# Altium schematic sheet HEATER.SchDoc(HEATER)
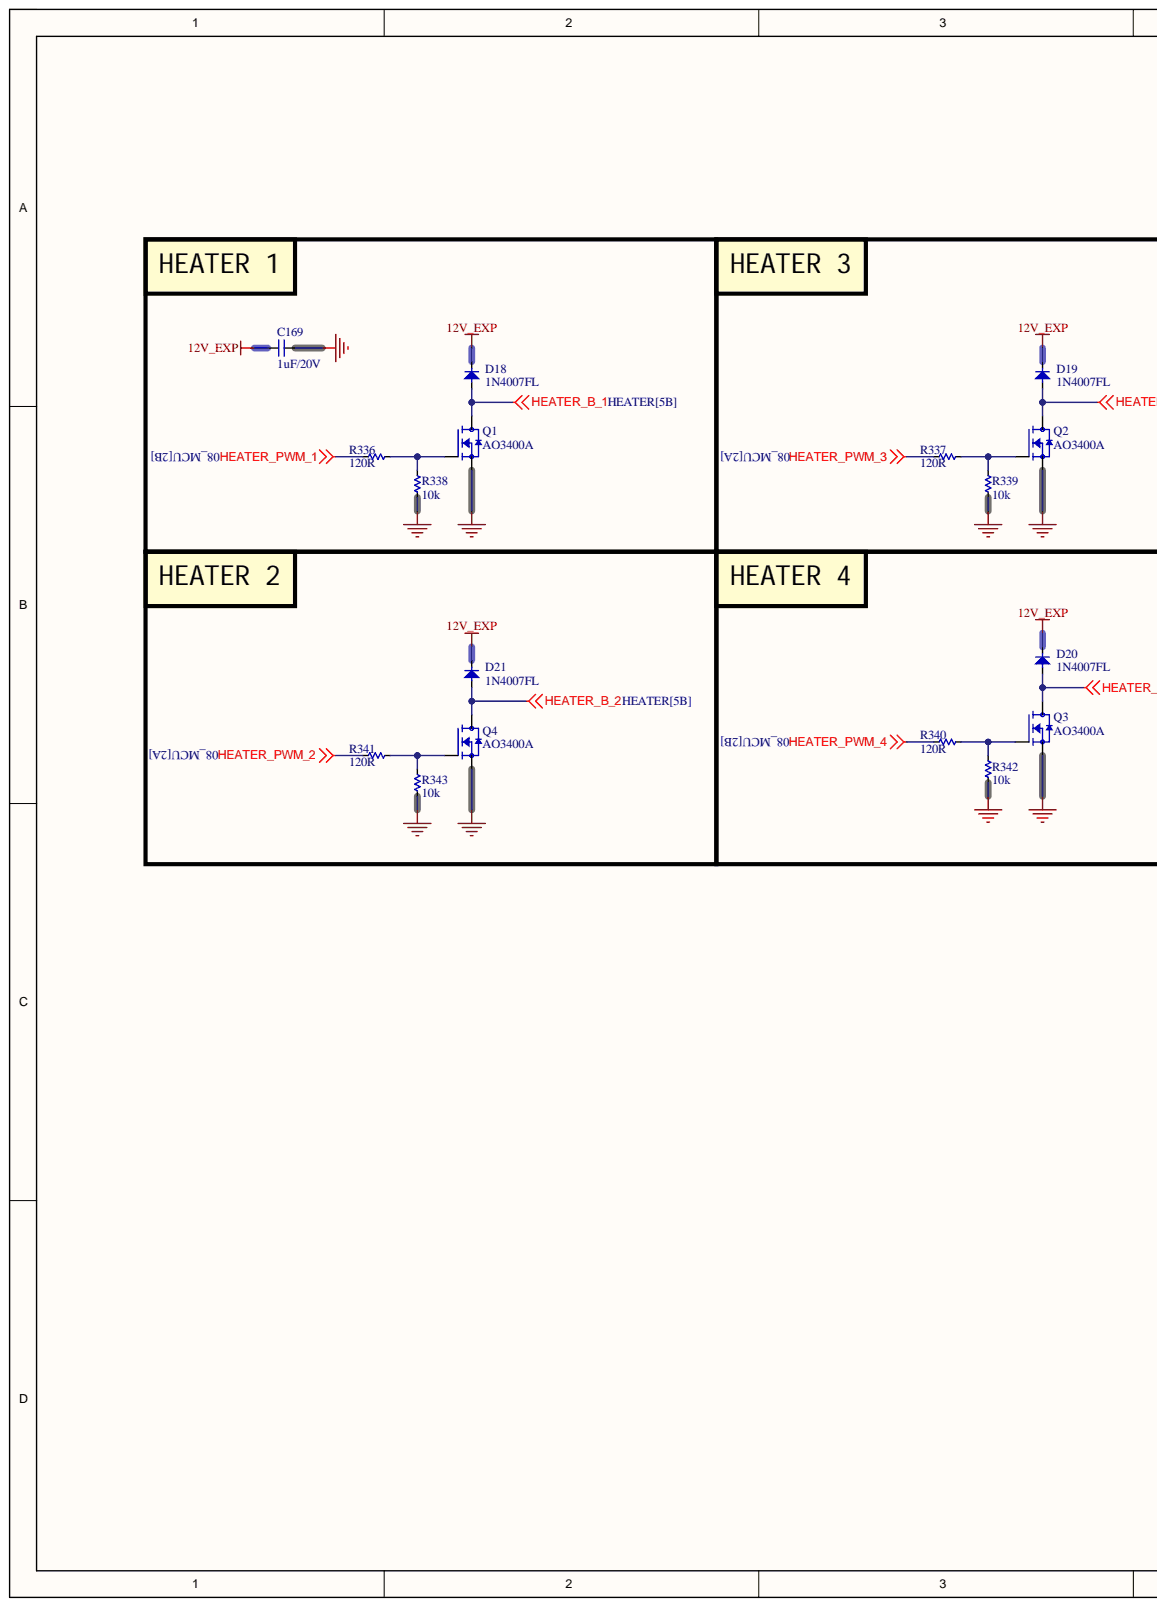
[diagram p0: HEATER.SchDoc, left]
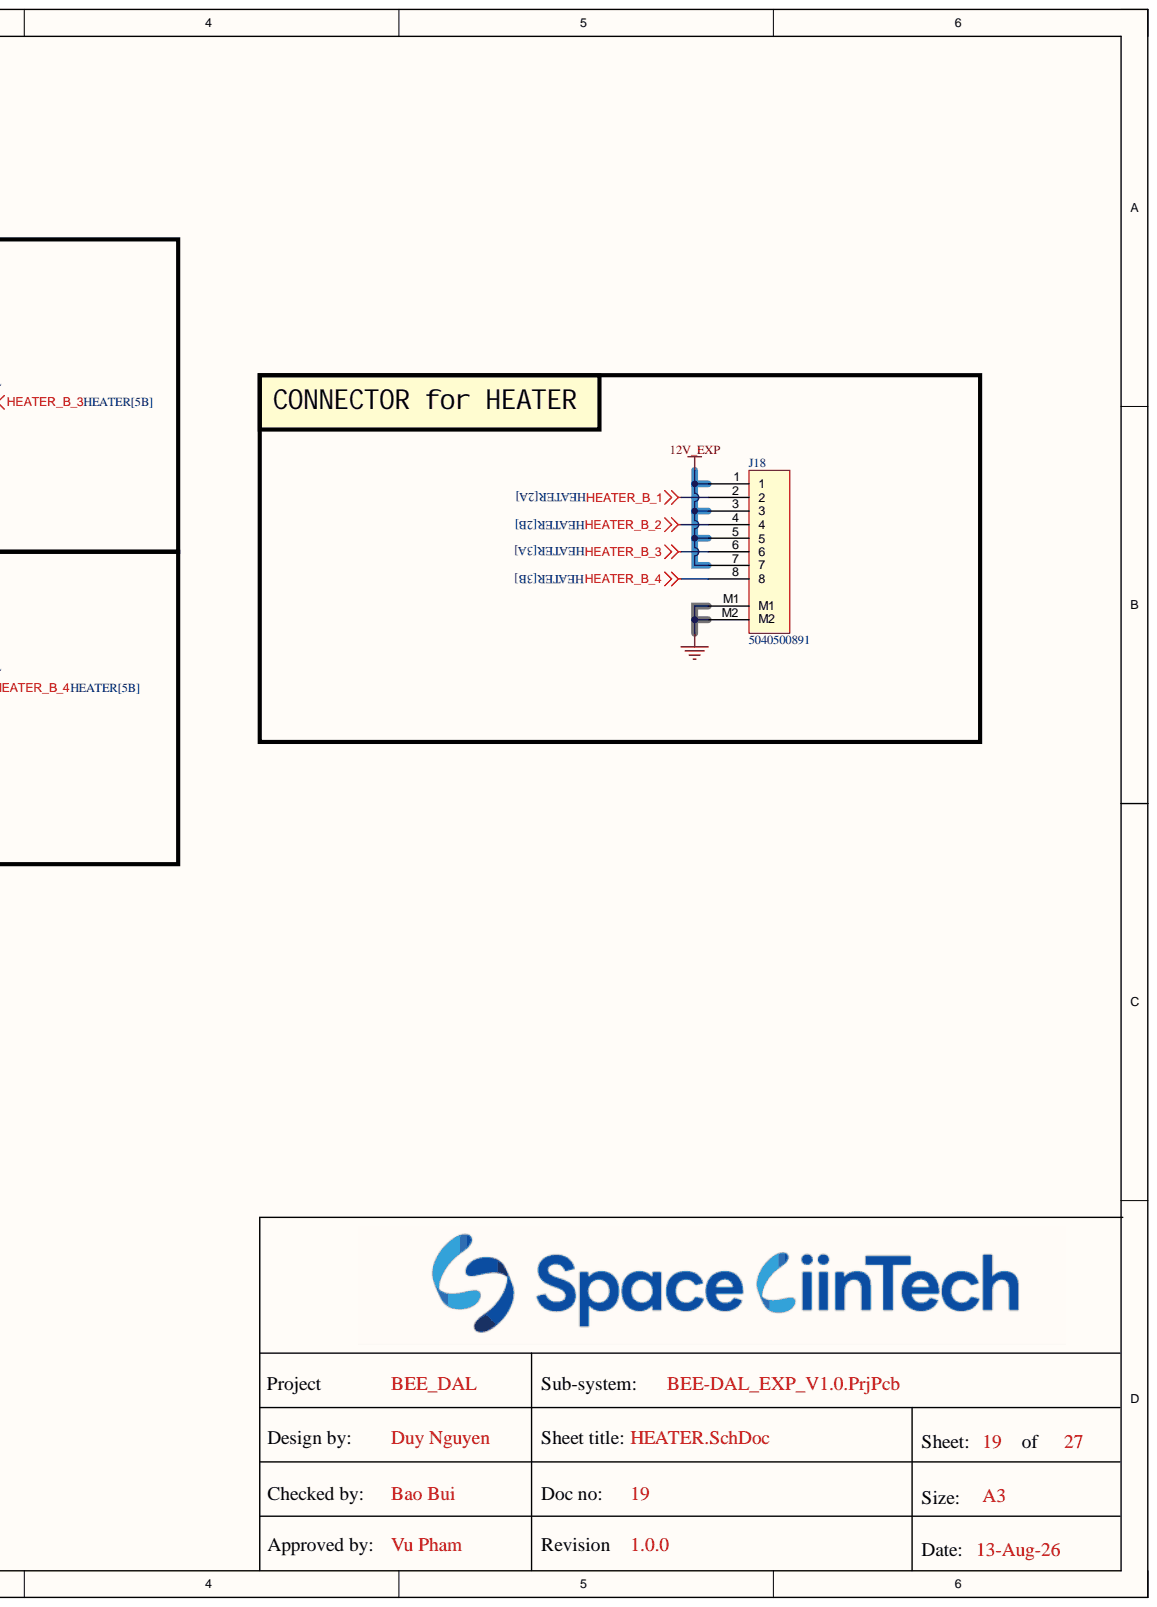
[diagram p1: HEATER.SchDoc, right]

## Parts
C169  1uF/20V  [CAP_C_1005X60]
D18  1N4007FL  [SOD3717X145N]
D19  1N4007FL  [SOD3717X145N]
D20  1N4007FL  [SOD3717X145N]
D21  1N4007FL  [SOD3717X145N]
J18  5040500891  [MOLEX_5040500891]
Q1  AO3400A  [SOT95P240X120-3N]
Q2  AO3400A  [SOT95P240X120-3N]
Q3  AO3400A  [SOT95P240X120-3N]
Q4  AO3400A  [SOT95P240X120-3N]
R336  120R  [RES_C_1005X40]
R337  120R  [RES_C_1005X40]
R338  10k  [RES_C_1005X40]
R339  10k  [RES_C_1005X40]
R340  120R  [RES_C_1005X40]
R341  120R  [RES_C_1005X40]
R342  10k  [RES_C_1005X40]
R343  10k  [RES_C_1005X40]

## Nets
12V_EXP: C169.2 D18.1 D19.1 D20.1 D21.1 J18.1 J18.3 J18.5 J18.7
GND: C169.1 J18.M1 J18.M2 Q1.2 Q2.2 Q3.2 Q4.2 R338.1 R339.1 R342.1 R343.1
HEATER_B_1: D18.2 J18.2 Q1.3
HEATER_B_2: D21.2 J18.4 Q4.3
HEATER_B_3: D19.2 J18.6 Q2.3
HEATER_B_4: D20.2 J18.8 Q3.3
HEATER_PWM_1: R336.1
HEATER_PWM_2: R341.1
HEATER_PWM_3: R337.1
HEATER_PWM_4: R340.1
NetQ1_1: Q1.1 R336.2 R338.2
NetQ2_1: Q2.1 R337.2 R339.2
NetQ3_1: Q3.1 R340.2 R342.2
NetQ4_1: Q4.1 R341.2 R343.2

## Library data of the parts
C169 = _CAP_C_2: CAP; Component Kind: Standard; Library Name: LABLIB.SchLib
D18-D21 = Diode SMD: Default Diode; Code - JEDEC: DO-214-AB; Value: 1N5007; Component Kind: Standard; Published: 8-Jun-2000; Revision: July-2002: Re-released for DXP Platform.; Library Name: LABLIB.SchLib; Publisher: Altium Limited
J18 = Header 8x1_2M: Component Kind: Standard; Library Name: LABLIB.SchLib
Q1-Q4 = MOSFET_N: N-Channel 30 V 5.7A (Ta) 1.4W (Ta) Surface Mount SOT-23-3; MF: Alpha & Omega Semiconductor; Check_prices: https://www.snapeda.com/parts/AO3400A/Alpha/view-part/?ref=eda; Component Kind: Standard; MP: AO3400A; Package: SOT-23 Alpha &amp; Omega Semiconductor Inc.; SnapEDA_Link: https://www.snapeda.com/parts/AO3400A/Alpha/view-part/?ref=snap; Library Name: LABLIB.SchLib
R336-R343 = _RES_B_2: RES; Component Kind: Standard; Library Name: LABLIB.SchLib
